# Altium schematic sheet TRAMPA_DE_GRASA.SchDoc
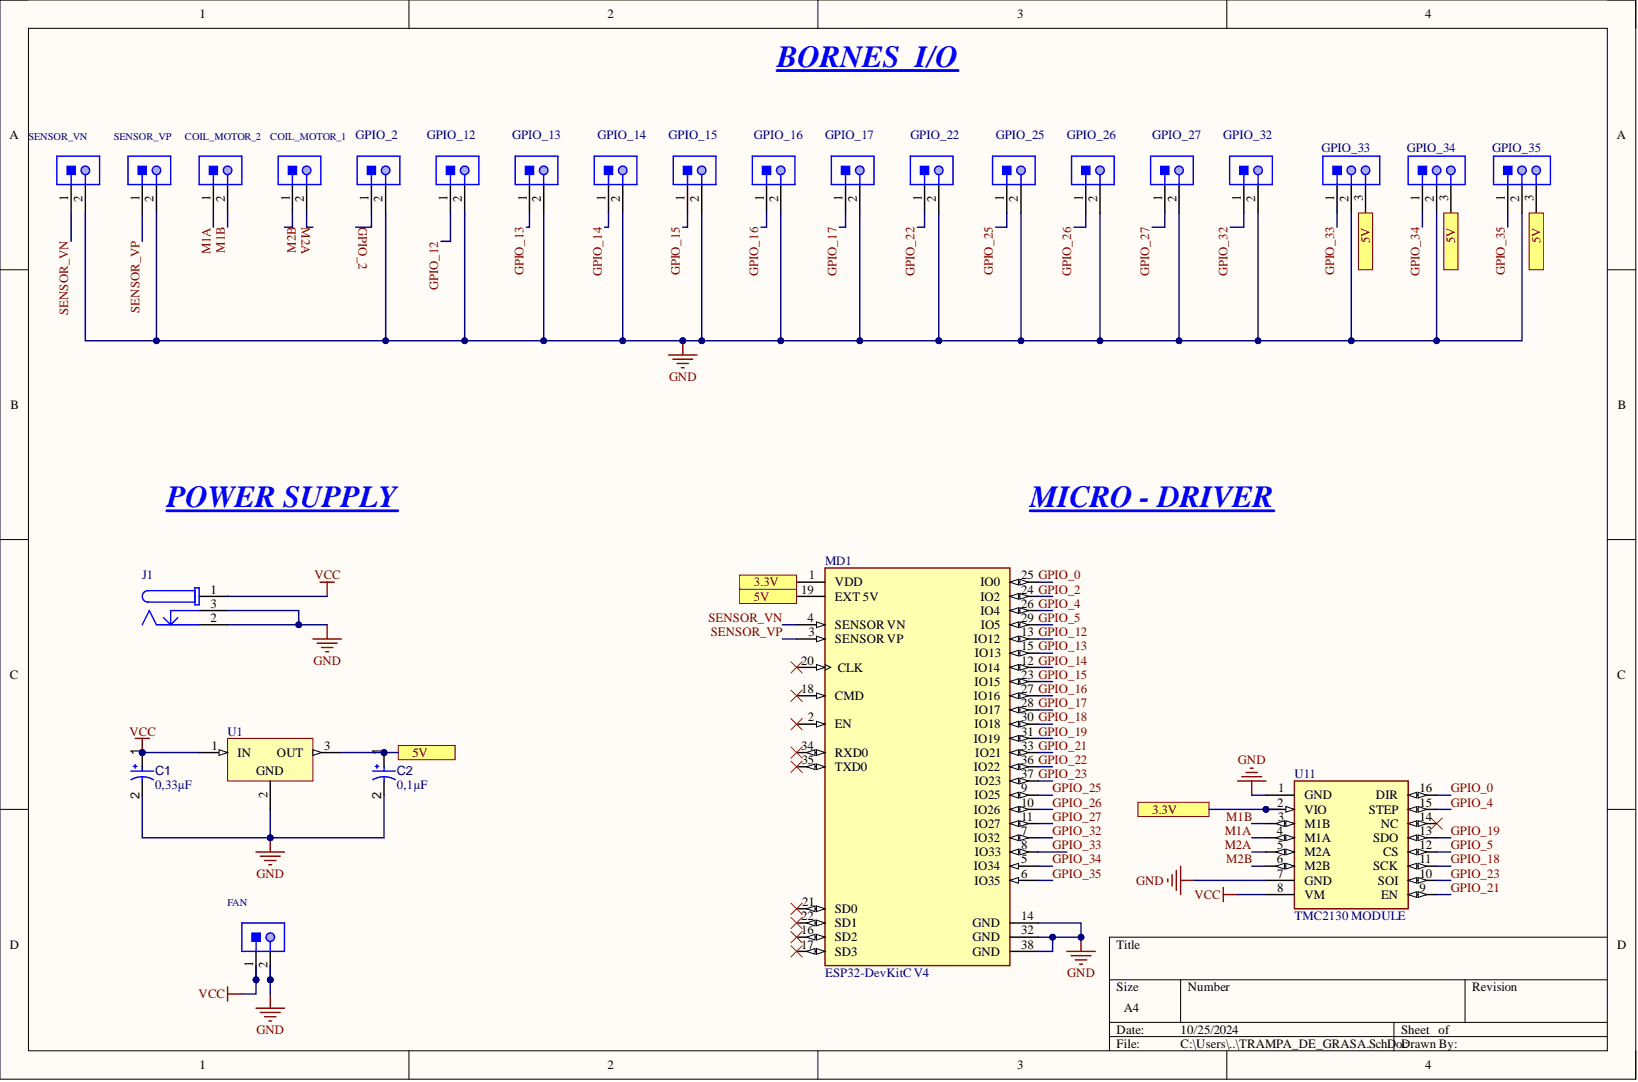
[diagram p0: TRAMPA_DE_GRASA.SchDoc]

## Parts
C1  value 0,33µF  [CAPPR55-200-1100X500X1200]  (hidden comment: ECA1HM220)
C2  value 0,1µF  [CAPPR55-200-1100X500X1200]  (hidden comment: ECA1HM220)
COIL_MOTOR_1  691312510002  [691312510002]  (value not drawn)
COIL_MOTOR_2  691312510002  [691312510002]  (value not drawn)
FAN  691312510002  [691312510002]  (value not drawn)
GPIO_2  691312510002  [691312510002]  (value not drawn)
GPIO_12  691312510002  [691312510002]  (value not drawn)
GPIO_13  691312510002  [691312510002]  (value not drawn)
GPIO_14  691312510002  [691312510002]  (value not drawn)
GPIO_15  691312510002  [691312510002]  (value not drawn)
GPIO_16  691312510002  [691312510002]  (value not drawn)
GPIO_17  691312510002  [691312510002]  (value not drawn)
GPIO_22  691312510002  [691312510002]  (value not drawn)
GPIO_25  691312510002  [691312510002]  (value not drawn)
GPIO_26  691312510002  [691312510002]  (value not drawn)
GPIO_27  691312510002  [691312510002]  (value not drawn)
GPIO_32  691312510002  [691312510002]  (value not drawn)
GPIO_33  691312710003  [691312710003]  (value not drawn)
GPIO_34  691312710003  [691312710003]  (value not drawn)
GPIO_35  691312710003  [691312710003]  (value not drawn)
J1  694106301002  [694106301002]  (value not drawn)
MD1  ESP32-DevKitC V4  Max Supply Voltage: 5V  [FP-ESP32-DevKitC_V4-MFG]
SENSOR_VN  691312510002  [691312510002]  (value not drawn)
SENSOR_VP  691312510002  [691312510002]  (value not drawn)
U1  LM7805CT  [FAIR-TO-220-3]  (value not drawn)
U11  TMC2130 MODULE  [TMC2130]

## Ports
3.3V, 5V

## Nets
GND: C1.2 C2.2 FAN.2 GPIO_2.2 GPIO_12.2 GPIO_13.2 GPIO_14.2 GPIO_15.2 GPIO_16.2 GPIO_17.2 GPIO_22.2 GPIO_25.2 GPIO_26.2 GPIO_27.2 GPIO_32.2 GPIO_33.2 GPIO_34.2 GPIO_35.2 J1.2 J1.3 MD1.14(GND) MD1.32(GND) MD1.38(GND) SENSOR_VN.2 SENSOR_VP.2 U1.2 U11.1(GND) U11.7(GND)
VCC: C1.1 FAN.1 J1.1 U1.1 U11.8(VM)
3.3V: MD1.1(VDD) U11.2(VIO) ; port 3.3V
5V: C2.1 GPIO_33.3 GPIO_34.3 GPIO_35.3 MD1.19(EXT_5V) U1.3 ; port 5V
GPIO_0: MD1.25(IO0) U11.16(DIR)
GPIO_2: GPIO_2.1 MD1.24(IO2)
GPIO_4: MD1.26(IO4) U11.15(STEP)
GPIO_5: MD1.29(IO5) U11.12(CS)
GPIO_12: GPIO_12.1 MD1.13(IO12)
GPIO_13: GPIO_13.1 MD1.15(IO13)
GPIO_14: GPIO_14.1 MD1.12(IO14)
GPIO_15: GPIO_15.1 MD1.23(IO15)
GPIO_16: GPIO_16.1 MD1.27(IO16)
GPIO_17: GPIO_17.1 MD1.28(IO17)
GPIO_18: MD1.30(IO18) U11.11(SCK)
GPIO_19: MD1.31(IO19) U11.13(SDO)
GPIO_21: MD1.33(IO21) U11.9(EN)
GPIO_22: GPIO_22.1 MD1.36(IO22)
GPIO_23: MD1.37(IO23) U11.10(SOI)
GPIO_25: GPIO_25.1 MD1.9(IO25)
GPIO_26: GPIO_26.1 MD1.10(IO26)
GPIO_27: GPIO_27.1 MD1.11(IO27)
GPIO_32: GPIO_32.1 MD1.7(IO32)
GPIO_33: GPIO_33.1 MD1.8(IO33)
GPIO_34: GPIO_34.1 MD1.5(IO34)
GPIO_35: GPIO_35.1 MD1.6(IO35)
M1A: COIL_MOTOR_2.1 U11.4(M1A)
M1B: COIL_MOTOR_2.2 U11.3(M1B)
M2A: COIL_MOTOR_1.2 U11.5(M2A)
M2B: COIL_MOTOR_1.1 U11.6(M2B)
SENSOR_VN: MD1.4(SENSOR_VN) SENSOR_VN.1
SENSOR_VP: MD1.3(SENSOR_VP) SENSOR_VP.1

Not connected: MD1 2(EN) 16(SD2) 17(SD3) 18(CMD) 20(CLK) 21(SD0) 22(SD1) 34(RXD0) 35(TXD0); U11 14(NC)

## Library data of the parts
C1 C2 = CMP-001-00027-5: Aluminum Electrolytic Capacitor, 22 uF, +/- 20%, 50 V, -40 to 85 degC, 2-Pin THD, RoHS, Bulk; Manufacturer: Panasonic; Ripple Current: 100mA; Voltage Rating: 50V; Capacitor Type: Electrolytic; Case/Package: Radial; Component Kind: Standard; Datasheet URL: https://industrial.panasonic.com/cdbs/www-data/pdf/RDF0000/ABA0000C1218.pdf; Datasheet Version: Rev. 05, 10/2016; Diameter: 5mm; Lead Pitch: 2mm; Manufacturer URL: http://www.panasonic.com/; Max Operating Temperature: 85°C; Min Operating Temperature: -40°C; Mounting Technology: TH; Package Description: 2-Pin Radial Lead, Dia 5 mm, Height 12 mm; Package Quantity: 200; Package Reference: CAPPR55-200-1100X500X1200; Packaging: Bulk; Pins: 2; Ripple Current (AC): 100mA; RoHS Compliant: True; Tolerance: 20%; Library Name: Pontificia Universidad Javeriana - Cali
COIL_MOTOR_1 COIL_MOTOR_2 FAN GPIO_2 GPIO_12-GPIO_17 GPIO_22 GPIO_25-GPIO_27 GPIO_32 SENSOR_VN SENSOR_VP = CMP-1502-02169-1: Serie 312 - 5.08mm Open Horizontal PCB Header WR-TBL, 2 pin; Manufacturer: Wurth Elektronik; Gender: Male; Pin Number: 2; Component Kind: Standard; Datasheet URL: http://katalog.we-online.de/em/datasheet/6913125100xx.pdf; Library Name: Altium Content Vault; Manufacturer URL: http://www.we-online.de; Mounting Technology: THT; Operating Temperature: -40to105degC; Package Reference: 691312510002; Packaging: Box; Part Number: 691312510002; Pitch: 5.08mm; Publisher: WurthElektronik
GPIO_33-GPIO_35 = CMP-1502-02041-1: Serie 3127 - 5.00mm Open Horizontal PCB Header WR-TBL, 3 pin; Manufacturer: Wurth Elektronik; Gender: Male; Pin Number: 3; Component Kind: Standard; Datasheet URL: http://katalog.we-online.de/em/datasheet/6913127100xx.pdf; Library Name: Altium Content Vault; Manufacturer URL: http://www.we-online.de; Mounting Technology: THT; Operating Temperature: -40to105degC; Package Reference: 691312710003; Packaging: Box; Part Number: 691312710003; Pitch: 5.00mm; Publisher: WurthElektronik
J1 = CMP-1502-00188-1: DC Power Jack Connector WR-DC, Male, Right Angled, THT, 5 A, 24 V; Manufacturer: Wurth Elektronik; Opening Diameter: 6.4mm; Plug Inner Diameter: 2.05mm; Plug Outer Diameter: 5.5mm; Rated Current: 5A; Working Voltage: 24V; Component Kind: Standard; Datasheet URL: http://katalog.we-online.de/em/datasheet/6941xx301002.pdf; Gender: Male; Library Name: Altium Content Vault; Manufacturer URL: http://www.we-online.de; Mounting Technology: THT; Operating Temperature: -40to85degC; Orientation: RightAngled; Package Reference: 694106301002; Packaging: Bag; Part Number: 694106301002; Publisher: WurthElektronik
MD1 = CMP-194065-000004-1: WiFi Development Tools (802.11) ESP32 General Development Kit, ESP32-WROOM-32 on the board; Manufacturer: Espressif; Bandwidth: 2.4-2.5GHz; Interface: I2C,SPI,UART,I2S; Max Frequency: 2.5GHz; Min Supply Voltage: 2.7V; Number Of Adc Channels: 16; Number Of Gpio: 22; Automotive: No; Category: UNK; Component Kind: Standard; ComponentLink2Description: Datasheet; ComponentLink2URL: https://docs.espressif.com/projects/esp-idf/en/latest/get-started/get-started-devkitc.html; Device Class L1: Integrated Circuits (ICs); Device Class L2: RF Semiconductors and Devices; Device Class L3: Transceivers; Lead Free: Yes; Library Name: Altium Content Vault; Manufacturer Part Number: ESP32-DevKitC V4; Octopart: https://octopart.com/esp32-devkitc+v4-espressif+systems-107467262; Package: ESP32-DevKitC V4; RoHS: TRUE; Temperature: -40°C to; Temperature Range Low: -40°C
U1 = CMP-2000-04938-1: Positive Voltage Regulator, 5 V, 1 A, -40 to 125 degC, 3-Pin TO-220, RoHS, Tube; Manufacturer: FairchildSemiconductor; Accuracy: ±4%; Line Regulation: 100mV; Maximum Input Voltage: 35V; Maximum Output Current: 2.2(Typ)A; Maximum Quiescent Current: 8mA; Number of Outputs: 1; Output Type: Fixed; Output Voltage: 5V; Rad Hard: No; Typical Dropout Voltage @ Current: 2@1AV; Category: LinearRegulator; Component Kind: Standard; Datasheet URL: https://datasheet.ciiva.com/26904/lm7805ct-26904988.pdf; Datasheet Version: Aug-12; Library Name: Altium Content Vault; Load Regulation: 100mV; Manufacturer URL: https://www.fairchildsemi.com/; Mounting: ThroughHole; Operating Temperature: -40to125degC; Package: 3TO-220AB; Package Description: 3-Pin Through Hole Device, Body 10 x 4.5 mm, Pitch 2.54 mm; Package Reference: TO-220; Package URL: https://www.fairchildsemi.com/package-drawings/TO/TO220B03.pdf; Packaging: Rail/Tube; Polarity: Positive; Type: Linear
U11 = TMC2130 MODULE: Component Kind: Standard; Description: TMC2130 MODULE; Library Name: TMC2130.SchLib
